# Altium schematic sheet uC.SchDoc
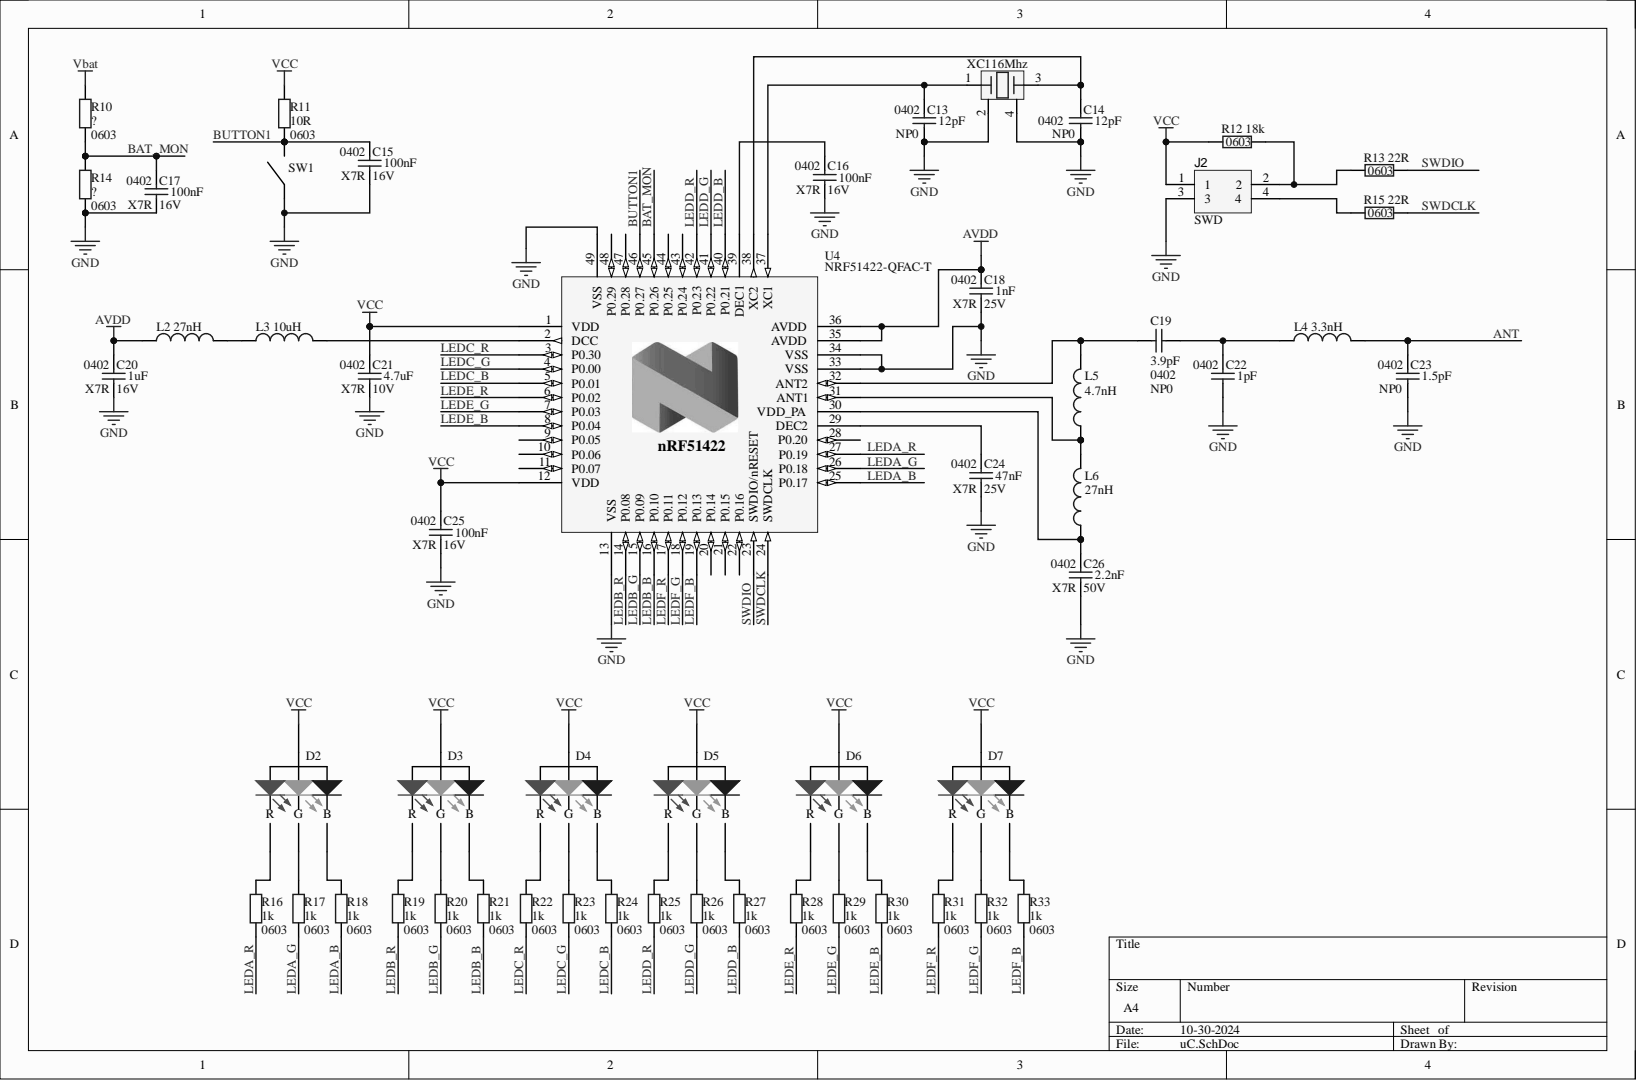
[diagram p0: uC.SchDoc]

## Parts
C13  Capacitance: 12pF  Case/Package: 0402  Dielectric Characteristic: NP0  [CAPC1005X56X25NL10T15]
C14  Capacitance: 12pF  Dielectric Characteristic: NP0  [CAPC1005X56X25NL10T15]
C15  Capacitance: 100nF  Case/Package: 0402  Dielectric Characteristic: X7R  Voltage Rating (DC): 16V  [CAPC1005X56X25NL10T15]
C16  Capacitance: 100nF  Case/Package: 0402  Dielectric Characteristic: X7R  Voltage Rating (DC): 16V  [CAPC1005X56X25NL10T15]
C17  Capacitance: 100nF  Case/Package: 0402  Dielectric Characteristic: X7R  Voltage Rating (DC): 16V  [CAPC1005X56X25NL10T15]
C18  Capacitance: 1nF  Case/Package: 0402  Dielectric Characteristic: X7R  Voltage Rating (DC): 25V  [CAPC1005X56X25NL10T15]
C19  Capacitance: 3.9pF  Case/Package: 0402  [CAPC1005X56X25NL10T15]
C20  Capacitance: 1uF  Case/Package: 0402  Dielectric Characteristic: X7R  Voltage Rating (DC): 16V  [CAPC1005X56X25NL10T15]
C21  Capacitance: 4.7uF  Case/Package: 0402  Dielectric Characteristic: X7R  Voltage Rating (DC): 10V  [CAPC1005X56X25NL10T15]
C22  Capacitance: 1pF  Case/Package: 0402  [CAPC1005X56X25NL10T15]
C23  Capacitance: 1.5pF  Case/Package: 0402  Dielectric Characteristic: NP0  [CAPC1005X56X25NL10T15]
C24  Capacitance: 47nF  Case/Package: 0402  Dielectric Characteristic: X7R  Voltage Rating (DC): 25V  [CAPC1005X56X25NL10T15]
C25  Capacitance: 100nF  Case/Package: 0402  Dielectric Characteristic: X7R  Voltage Rating (DC): 16V  [CAPC1005X56X25NL10T15]
C26  Capacitance: 2.2nF  Case/Package: 0402  Dielectric Characteristic: X7R  Voltage Rating (DC): 50V  [CAPC1005X56X25NL10T15]
D2  Led RGB  [PCB-5x94mfie5525cac7h9me-1]  (value not drawn)
D3  Led RGB  [PCB-5x94mfie5525cac7h9me-1]  (value not drawn)
D4  Led RGB  [PCB-5x94mfie5525cac7h9me-1]  (value not drawn)
D5  Led RGB  [PCB-5x94mfie5525cac7h9me-1]  (value not drawn)
D6  Led RGB  [PCB-5x94mfie5525cac7h9me-1]  (value not drawn)
D7  Led RGB  [PCB-5x94mfie5525cac7h9me-1]  (value not drawn)
J2  SWD  [PCB-cdnv24gaqn48skqo4cxs-1]
L2  Inductance: 27nH  [INDC1007X07N]
L3  Inductance: 10uH  [INDC1007X07N]
L4  Inductance: 3.3nH  [INDC1007X07N]
L5  Inductance: 4.7nH  [INDC1007X07N]
L6  Inductance: 27nH  [INDC1007X07N]
R10  (no value)  [0603]
R11  Resistance: 10R  [0603]
R12  Resistance: 18k  [0603]
R13  Resistance: 22R  [0603]
R14  (no value)  [0603]
R15  Resistance: 22R  [0603]
R16  Resistance: 1k  [0603]
R17  Resistance: 1k  [0603]
R18  Resistance: 1k  [0603]
R19  Resistance: 1k  [0603]
R20  Resistance: 1k  [0603]
R21  Resistance: 1k  [0603]
R22  Resistance: 1k  [0603]
R23  Resistance: 1k  [0603]
R24  Resistance: 1k  [0603]
R25  Resistance: 1k  [0603]
R26  Resistance: 1k  [0603]
R27  Resistance: 1k  [0603]
R28  Resistance: 1k  [0603]
R29  Resistance: 1k  [0603]
R30  Resistance: 1k  [0603]
R31  Resistance: 1k  [0603]
R32  Resistance: 1k  [0603]
R33  Resistance: 1k  [0603]
SW1  TE Connectivity 1437566-3 MM  [PCB-idw6w8o6l3qho24h0yag-1]  (value not drawn)
U4  NRF51422-QFAC-T  [PCB-ythwm3ramf0pbw43rc1e-1]
XC1  Freq: 16Mhz  [TSX-3225_AP761]  (hidden comment: Epson TSX-3225 16.0000MF09Z-AC3)

## Nets
AVDD: C18.2 C20.2 L2.1 U4.35(AVDD) U4.36(AVDD)
GND: C13.1 C14.1 C15.1 C16.1 C17.1 C18.1 C20.1 C21.1 C22.1 C23.1 C24.1 C25.1 C26.1 J2.3 R14.1 SW1.2 U4.13(VSS) U4.33(VSS) U4.34(VSS) U4.49(VSS) XC1.2 XC1.4
Vbat: R10.2
VCC: C21.2 C25.2 D2.4 D3.4 D4.4 D5.4 D6.4 D7.4 J2.1 R11.2 R12.2 U4.1(VDD) U4.12(VDD)
ANT: C23.2 L4.2
BAT_MON: C17.2 R10.1 R14.2 U4.45(P0.26)
BUTTON1: C15.2 R11.1 SW1.1 U4.46(P0.27)
LEDA_B: R18.1 U4.25(P0.17)
LEDA_G: R17.1 U4.26(P0.18)
LEDA_R: R16.1 U4.27(P0.19)
LEDB_B: R21.1 U4.16(P0.10)
LEDB_G: R20.1 U4.15(P0.09)
LEDB_R: R19.1 U4.14(P0.08)
LEDC_B: R24.1 U4.5(P0.01)
LEDC_G: R23.1 U4.4(P0.00)
LEDC_R: R22.1 U4.3(P0.30)
LEDD_B: R27.1 U4.40(P0.21)
LEDD_G: R26.1 U4.41(P0.22)
LEDD_R: R25.1 U4.42(P0.23)
LEDE_B: R30.1 U4.8(P0.04)
LEDE_G: R29.1 U4.7(P0.03)
LEDE_R: R28.1 U4.6(P0.02)
LEDF_B: R33.1 U4.19(P0.13)
LEDF_G: R32.1 U4.18(P0.12)
LEDF_R: R31.1 U4.17(P0.11)
SWDCLK: R15.1 U4.24(SWDCLK)
SWDIO: R13.1 U4.23(SWDIO/nRESET)
NetC13_2: C13.2 U4.37(XC1) XC1.1
NetC14_2: C14.2 U4.38(XC2) XC1.3
NetC16_2: C16.2 U4.39(DEC1)
NetC19_1: C19.1 C22.2 L4.1
NetC19_2: C19.2 L5.2 U4.32(ANT2)
NetC24_2: C24.2 U4.29(DEC2)
NetC26_2: C26.2 L6.1 U4.30(VDD_PA)
NetD2_1: D2.1(R) R16.2
NetD2_2: D2.2(G) R17.2
NetD2_3: D2.3(B) R18.2
NetD3_1: D3.1(R) R19.2
NetD3_2: D3.2(G) R20.2
NetD3_3: D3.3(B) R21.2
NetD4_1: D4.1(R) R22.2
NetD4_2: D4.2(G) R23.2
NetD4_3: D4.3(B) R24.2
NetD5_1: D5.1(R) R25.2
NetD5_2: D5.2(G) R26.2
NetD5_3: D5.3(B) R27.2
NetD6_1: D6.1(R) R28.2
NetD6_2: D6.2(G) R29.2
NetD6_3: D6.3(B) R30.2
NetD7_1: D7.1(R) R31.2
NetD7_2: D7.2(G) R32.2
NetD7_3: D7.3(B) R33.2
NetJ2_2: J2.2 R12.1 R13.2
NetJ2_4: J2.4 R15.2
NetL2_2: L2.2 L3.1
NetL3_2: L3.2 U4.2(DCC)
NetL5_1: L5.1 L6.2 U4.31(ANT1)

Not connected: U4 9(P0.05) 10(P0.06) 11(P0.07) 20(P0.14) 21(P0.15) 22(P0.16) 28(P0.20) 43(P0.24) 44(P0.25) 47(P0.28) 48(P0.29)

## Library data of the parts
C13 C14 C23 = CMP-126491-2: Capacitance Tolerance: ±5%; Voltage Rating (DC): 50V; Component Kind: Standard; Library Name: CommunityVault
  C14: Case/Package: 0402
C15-C18 C20 C21 C24-C26 = CMP-126491-2: Capacitance Tolerance: ±5%; Component Kind: Standard; Library Name: CommunityVault
C19 C22 = CMP-126491-2: Capacitance Tolerance: ±5%; Dielectric Characteristic: NP0; Voltage Rating (DC): 50V; Component Kind: Standard; Library Name: CommunityVault
D2-D7 = CMP-eotsd02ujfoltwjxkvzh-1: 1.6mm*1.6mm*0.55mm,0606; Component Kind: Standard; CustomComponentID: eotsd02ujfoltwjxkvzh; Library Name: FavoritesLibrary
J2 = CMP-2ffce6f22d89f03b-2: Conn Unshrouded Header HDR 4 POS 2.54mm Solder ST Thru-Hole Automotive Bulk; Manufacturer Part Number: TSW-102-07-G-D; Gender: Male; Lead-Free Status: Lead Free; Number of Contacts: 4; Pin Pitch: 0.00254 m; Component Kind: Standard; Contact Material: Phosphor Bronze; Contact Plating: Gold; Housing Color: Black; Housing Material: Plastic; Library Name: CommunityVault; Mounting Style: Through Hole; Number of Positions: 4; Number of Rows: 2; Octopart URL: http://octopart.com/tsw-102-07-g-d-samtec-272147; OctopartID: 2ffce6f22d89f03b; REACH SVHC Compliance: No SVHC; RoHS: Compliant; Size-Height: 0.00254 m; Size-Length: 0.00508 m; Size-Width: 0.00508 m
L2-L6 = CMP-fcd335a8272b2314-1: Case/Package: 0402; Component Kind: Standard; Library Name: CommunityVault
R10-R33 = CMP-8f45450282185082-2: Resistance Tolerance: ±5%; Component Kind: Standard; Library Name: CommunityVault; Package: 0603
  R10 R14: Resistance: ?
SW1 = CMP-5fef948f50048028-9: Switch Tactile OFF (ON) SPST Rectangular Button Gull Wing 0.05A 24VDC SMD Loose Piece; Actuator Type: Standard; Contact Current Rating: 50.0 mA; ELV Compliant: Compliant; Lead-Free Status: Lead Free; Component Kind: Standard; Contact Material: Brass; Contact Plating: Silver; Contacts Type: SPST; Library Name: CommunityVault; Lifecycle Status: Active; Manufacturer Part Number: 1437566-3; Mounting Style: Surface Mount; Octopart URL: http://octopart.com/1437566-3-te+connectivity-40512141; OctopartID: 5fef948f50048028; Orientation: Vertical; Packaging: Bag; REACH SVHC Compliance: No SVHC; RoHS: Compliant; Size-Length: 6.00 mm; Size-Width: 3.50 mm
U4 = CMP-b31f7904cc376cd8-3: Bluetooth v3.1 (BLE) SMART SOC 2Mbps 3V Medical 48-Pin QFN EP; Component Kind: Standard; Library Name: CommunityVault; Manufacturer Part Number: NRF51422-QFAC-T; Mounting Style: Surface Mount; Octopart URL: http://octopart.com/nrf51422-qfac-t-nordic+semiconductor-51468878
XC1 = CMP-a4096f8e6ba27bce-1: Epson Toyocom; Lead-Free Status: Lead Free; Component Kind: Standard; Library Name: CommunityVault; Manufacturer Part Number: TSX-3225 16.0000MF09Z-AC3; Octopart Url: http://octopart.com/tsx-3225+16.0000mf09z-ac3-epson-42855117; OctopartID: a4096f8e6ba27bce; RoHS: Compliant; Seller Landing  Url: https://octopart-clicks.com/click/track?country=US&ak=8157f166&sig=0dd4918&sid=5489&ppid=42855117&vpid=127576703&ct=offers
